# Altium schematic sheet CameraBoard.SchDoc
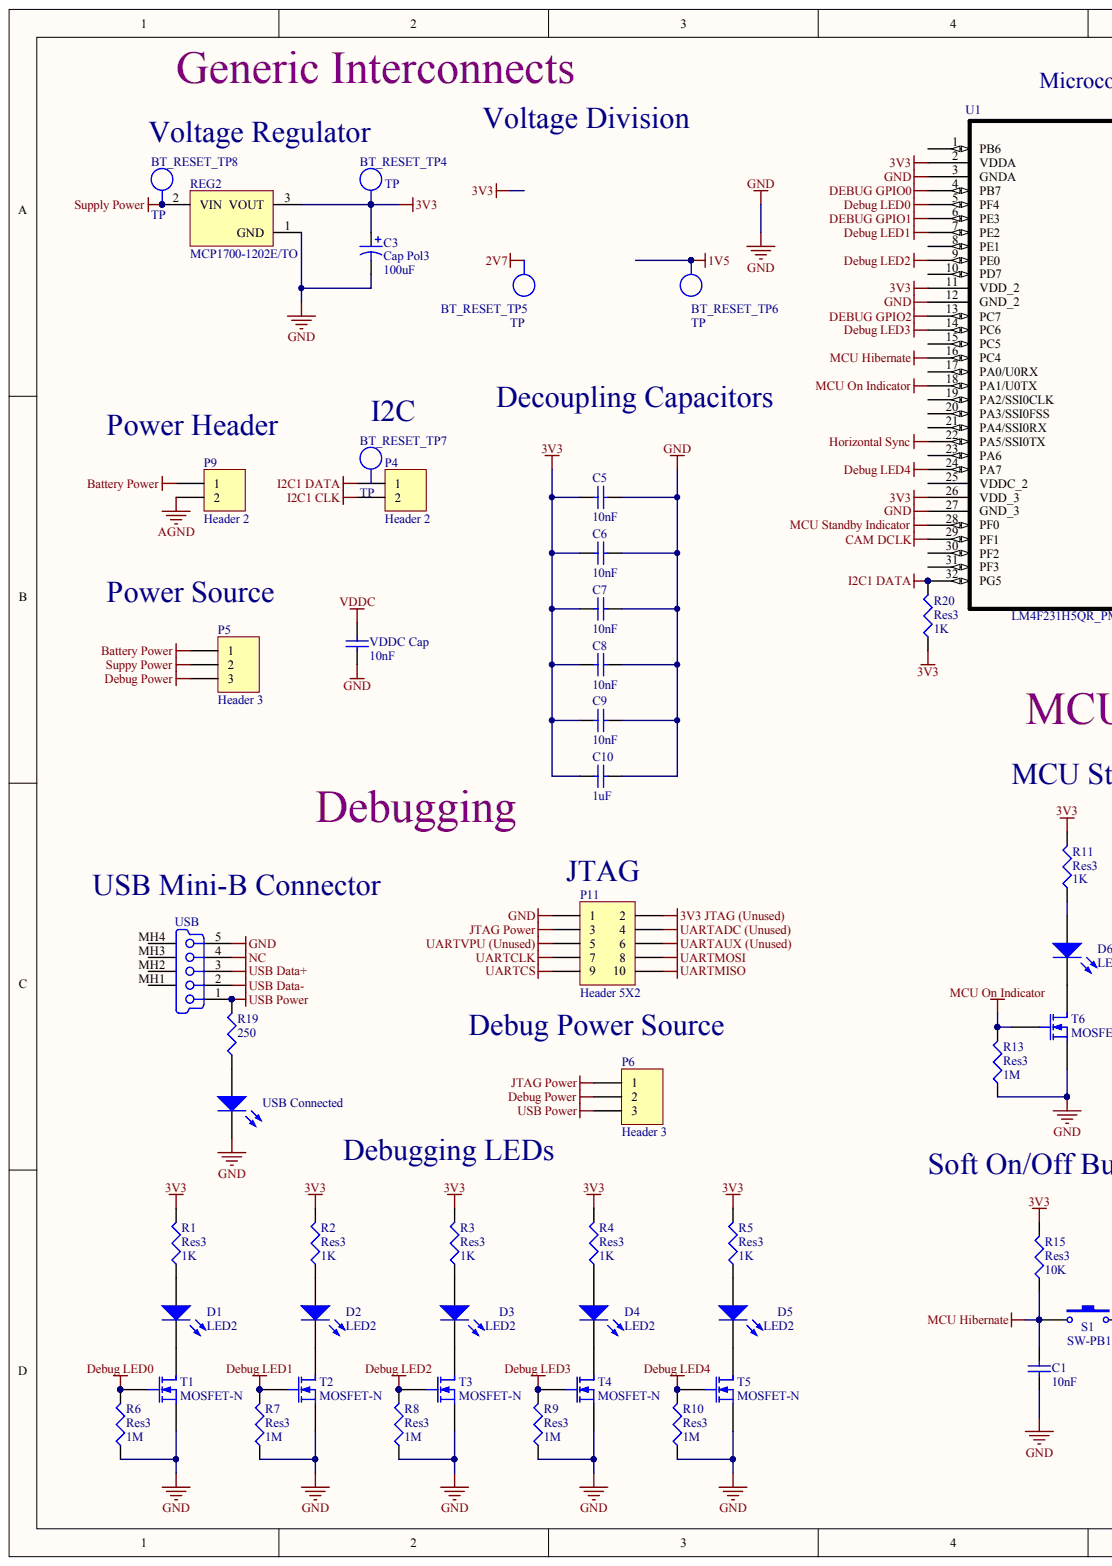
[diagram p0: CameraBoard.SchDoc, left]
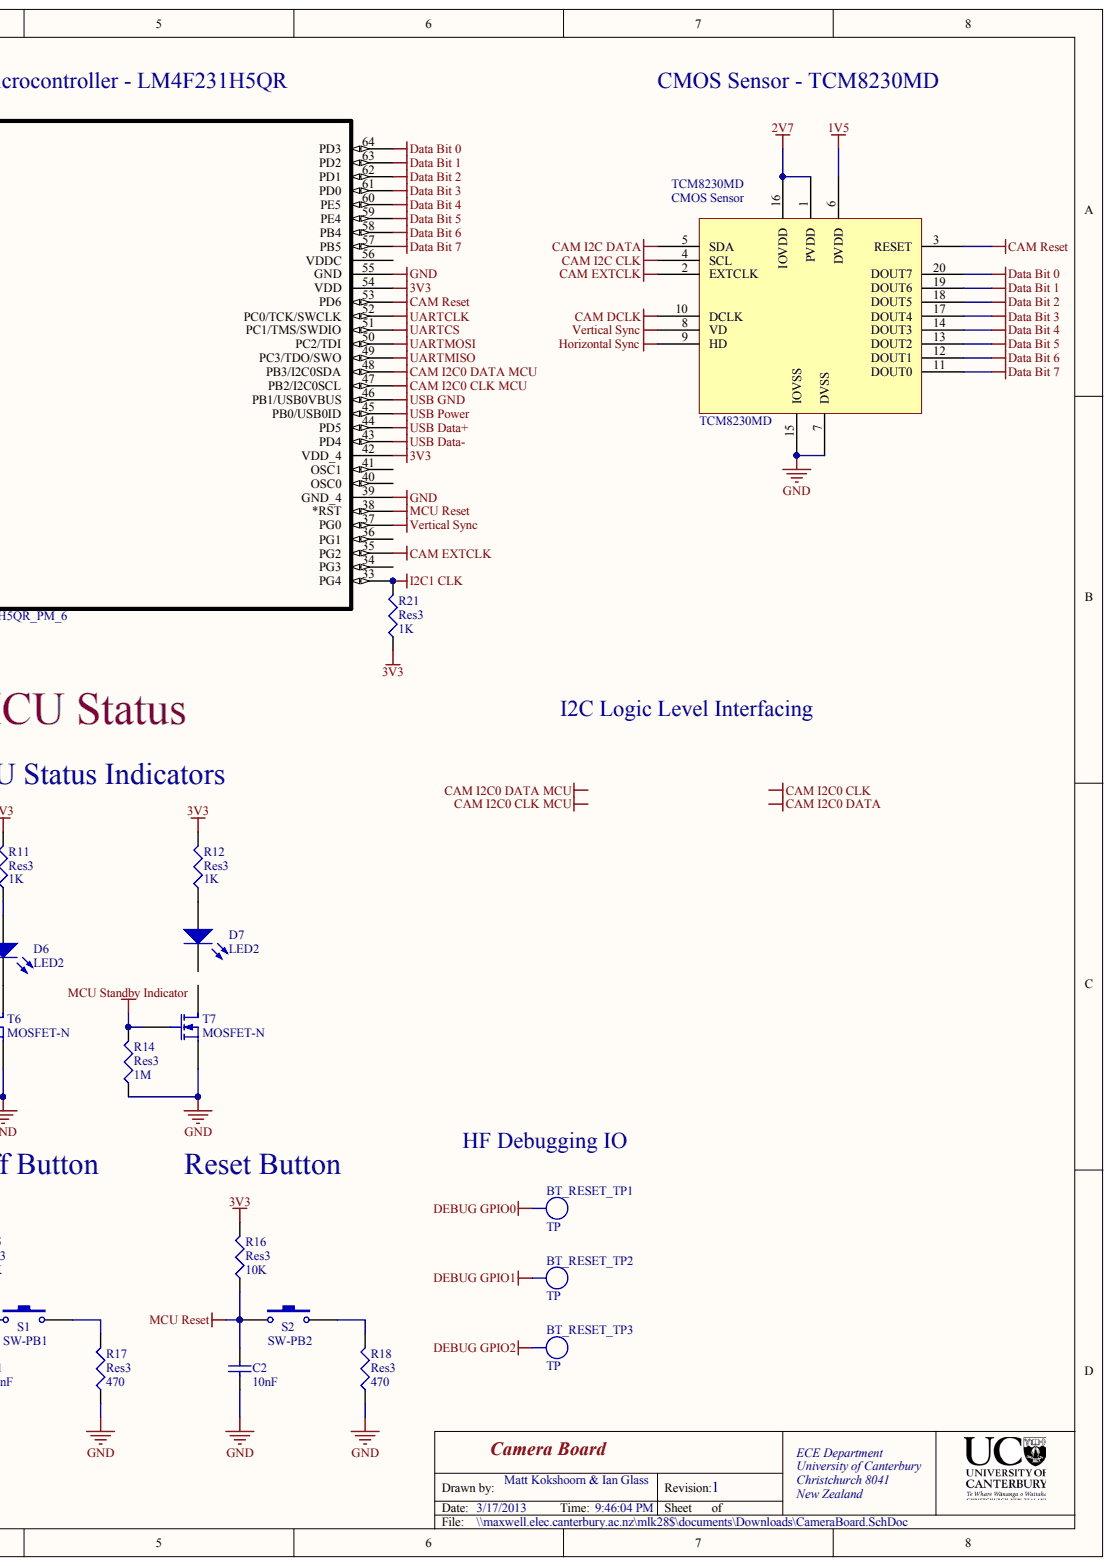
[diagram p1: CameraBoard.SchDoc, right]

## Parts
BT_RESET_TP1  TP  [TEST_POINT_4X2MM]  (no BOM)
BT_RESET_TP2  TP  [TEST_POINT_4X2MM]  (no BOM)
BT_RESET_TP3  TP  [TEST_POINT_4X2MM]  (no BOM)
BT_RESET_TP4  TP  [TEST_POINT_4X2MM]  (no BOM)
BT_RESET_TP5  TP  [TEST_POINT_4X2MM]  (no BOM)
BT_RESET_TP6  TP  [TEST_POINT_4X2MM]  (no BOM)
BT_RESET_TP7  TP  [TEST_POINT_4X2MM]  (no BOM)
BT_RESET_TP8  TP  [TEST_POINT_4X2MM]  (no BOM)
C1  value 10nF  (hidden comment: Cap Semi)
C2  value 10nF  (hidden comment: Cap Semi)
C3  Cap Pol3  value 100uF
C5  value 10nF  (hidden comment: Cap Semi)
C6  value 10nF  (hidden comment: Cap Semi)
C7  value 10nF  (hidden comment: Cap Semi)
C8  value 10nF  (hidden comment: Cap Semi)
C9  value 10nF  (hidden comment: Cap Semi)
C10  value 1uF  (hidden comment: Cap Semi)
D1  LED2
D2  LED2
D3  LED2
D4  LED2
D5  LED2
D6  LED2
D7  LED2
P4  Header 2
P5  Header 3
P6  Header 3
P9  Header 2
P11  Header 5X2
R1  Res3  value 1K
R2  Res3  value 1K
R3  Res3  value 1K
R4  Res3  value 1K
R5  Res3  value 1K
R6  Res3  value 1M
R7  Res3  value 1M
R8  Res3  value 1M
R9  Res3  value 1M
R10  Res3  value 1M
R11  Res3  value 1K
R12  Res3  value 1K
R13  Res3  value 1M
R14  Res3  value 1M
R15  Res3  value 10K
R16  Res3  value 10K
R17  Res3  value 470
R18  Res3  value 470
R19  value 250  (hidden comment: Res3)
R20  Res3  value 1K
R21  Res3  value 1K
REG2  MCP1700-1202E/TO
S1  SW-PB1
S2  SW-PB2
T1  MOSFET-N
T2  MOSFET-N
T3  MOSFET-N
T4  MOSFET-N
T5  MOSFET-N
T6  MOSFET-N
T7  MOSFET-N
TCM8230MD  CMOS Sensor
U1  LM4F231H5QR_PM_6
USB  0481900001  (value not drawn)
USB_Connected  LED3  (value not drawn)
VDDC_Cap  value 10nF  (hidden comment: Cap Semi)

## Nets
1V5: BT_RESET_TP6.1 TCM8230MD.6(DVDD)
2V7: BT_RESET_TP5.1 TCM8230MD.1(PVDD) TCM8230MD.16(IOVDD)
3V3: BT_RESET_TP4.1 C3.1 C5.2 C6.2 C7.2 C8.2 C9.2 C10.2 R1.2 R2.2 R3.2 R4.2 R5.2 R11.2 R12.2 R15.2 R16.2 R20.1 R21.1 REG2.3 U1.2(VDDA) U1.11(VDD_2) U1.26(VDD_3) U1.42(VDD_4) U1.54(VDD)
3V3 JTAG (UNUSED): P11.2
AGND: P9.2
BATTERY POWER: P5.1 P9.1
CAM DCLK: TCM8230MD.10(DCLK) U1.29(PF1)
CAM EXTCLK: TCM8230MD.2(EXTCLK) U1.35(PG2)
CAM I2C0 CLK MCU: U1.47(PB2/I2C0SCL)
CAM I2C0 DATA MCU: U1.48(PB3/I2C0SDA)
CAM I2C CLK: TCM8230MD.4(SCL)
CAM I2C DATA: TCM8230MD.5(SDA)
CAM RESET: TCM8230MD.3(RESET) U1.53(PD6)
DATA BIT 0: TCM8230MD.20(DOUT7) U1.64(PD3)
DATA BIT 1: TCM8230MD.19(DOUT6) U1.63(PD2)
DATA BIT 2: TCM8230MD.18(DOUT5) U1.62(PD1)
DATA BIT 3: TCM8230MD.17(DOUT4) U1.61(PD0)
DATA BIT 4: TCM8230MD.14(DOUT3) U1.60(PE5)
DATA BIT 5: TCM8230MD.13(DOUT2) U1.59(PE4)
DATA BIT 6: TCM8230MD.12(DOUT1) U1.58(PB4)
DATA BIT 7: TCM8230MD.11(DOUT0) U1.57(PB5)
DEBUG GPIO0: BT_RESET_TP1.1 U1.4(PB7)
DEBUG GPIO1: BT_RESET_TP2.1 U1.6(PE3)
DEBUG GPIO2: BT_RESET_TP3.1 U1.13(PC7)
DEBUG LED0: R6.2 T1.2 U1.5(PF4)
DEBUG LED1: R7.2 T2.2 U1.7(PE2)
DEBUG LED2: R8.2 T3.2 U1.9(PE0)
DEBUG LED3: R9.2 T4.2 U1.14(PC6)
DEBUG LED4: R10.2 T5.2 U1.24(PA7)
DEBUG POWER: P5.3 P6.2
GND: C1.2 C2.2 C3.2 C5.1 C6.1 C7.1 C8.1 C9.1 C10.1 P11.1 R6.1 R7.1 R8.1 R9.1 R10.1 R13.1 R14.1 R17.1 R18.1 REG2.1 T1.3 T2.3 T3.3 T4.3 T5.3 T6.3 T7.3 TCM8230MD.7(DVSS) TCM8230MD.15(IOVSS) U1.3(GNDA) U1.12(GND_2) U1.27(GND_3) U1.39(GND_4) U1.55(GND) USB.5 USB_Connected.2 VDDC_Cap.1
HORIZONTAL SYNC: TCM8230MD.9(HD) U1.22(PA5/SSI0TX)
I2C1 CLK: P4.2 R21.2 U1.33(PG4)
I2C1 DATA: P4.1 R20.2 U1.32(PG5)
JTAG POWER: P6.1 P11.3
MCU HIBERNATE: C1.1 R15.1 S1.1 U1.16(PC4)
MCU ON INDICATOR: R13.2 T6.2 U1.18(PA1/U0TX)
MCU RESET: C2.1 R16.1 S2.1 U1.38(*RST)
MCU STANDBY INDICATOR: R14.2 T7.2 U1.28(PF0)
NC: USB.4
SUPPLY POWER: BT_RESET_TP8.1 REG2.2
SUPPY POWER: P5.2
UARTADC (UNUSED): P11.4
UARTAUX (UNUSED): P11.6
UARTCLK: P11.7 U1.52(PC0/TCK/SWCLK)
UARTCS: P11.9 U1.51(PC1/TMS/SWDIO)
UARTMISO: P11.10 U1.49(PC3/TDO/SWO)
UARTMOSI: P11.8 U1.50(PC2/TDI)
UARTVPU (UNUSED): P11.5
USB DATA+: U1.44(PD5) USB.3
USB DATA-: U1.43(PD4) USB.2
USB GND: U1.46(PB1/USB0VBUS)
USB POWER: P6.3 R19.2 U1.45(PB0/USB0ID) USB.1
VDDC: VDDC_Cap.2
VERTICAL SYNC: TCM8230MD.8(VD) U1.37(PG0)
NetD1_1: D1.1 R1.1
NetD1_2: D1.2 T1.1
NetD2_1: D2.1 R2.1
NetD2_2: D2.2 T2.1
NetD3_1: D3.1 R3.1
NetD3_2: D3.2 T3.1
NetD4_1: D4.1 R4.1
NetD4_2: D4.2 T4.1
NetD5_1: D5.1 R5.1
NetD5_2: D5.2 T5.1
NetD6_1: D6.1 R11.1
NetD6_2: D6.2 T6.1
NetD7_1: D7.1 R12.1
NetR17_2: R17.2 S1.2
NetR18_2: R18.2 S2.2
NetR19_1: R19.1 USB_Connected.1
CAM I2C0 CLK:
CAM I2C0 DATA:

Not connected: BT_RESET_TP7 1; D7 2; T7 1; U1 1(PB6) 8(PE1) 10(PD7) 15(PC5) 17(PA0/U0RX) 19(PA2/SSI0CLK) 20(PA3/SSI0FSS) 21(PA4/SSI0RX) 23(PA6) 25(VDDC_2) 30(PF2) 31(PF3) 34(PG3) 36(PG1) 40(OSC0) 41(OSC1) 56(VDDC); USB MH1 MH2 MH3 MH4

## Library data of the parts
BT_RESET_TP1-BT_RESET_TP8 = TP_TESTPOINT: LatestRevisionDate: 29 May 08; Manufacturer PN: *; Manufacturer: *; Supplier 1: Digi-Key; Supplier Part Number 1: 5016KTR-ND; Value: TP; Part Number: TP_TESTPOINT; Component Type: Standard (No BOM); Designator: TP_TESTPOINT; Pin Count: 1
USB = (no symbol name): PCB_Layout: Complies with Manufacturer's Recommendation.; Published: 21-Sep-2007; DatasheetVersion: revA, May-2004; PackageVersion: May-2004; PackageReference: 481900001; PackageDescription: USB Mini-B, Sinking Type, SM; 5 Position; Right Angle, Body 11.45 x 11.8 x 3.9 mm (LxWxH); ComponentLink1Description: Manufacturer Link; ComponentLink1URL: http://www.molex.com/; ComponentLink2Description: Datasheet; ComponentLink2URL: http://www.molex.com/cmc_upload/0/000/895/958/SD-48190-001RevA.pdf; Publisher: Altium Limited
C1-C3 C5-C10 D1-D7 P4-P6 P9 P11 R1-R21 REG2 S1 S2 T1-T7 TCM8230MD U1 USB_Connected VDDC_Cap = (no symbol name)
  C1 C2 C5-C10 VDDC_Cap: Published: 8-Jun-2000; LatestRevisionDate: 29-May-2009; LatestRevisionNote: IPC-7351 Footprint Added.; PackageReference: C1206; PackageDescription: Chip Capacitor
  C3: Published: 8-Jun-2000; LatestRevisionDate: 29-May-2009; LatestRevisionNote: IPC-7351 Footprint Added.; PackageReference: C0805; PackageDescription: Chip Capacitor
  D1-D7: Published: 8-Jun-2000; LatestRevisionDate: 17-Jul-2002; LatestRevisionNote: Re-released for DXP Platform.; PackageVersion: Feb-2002; PackageReference: 3.2X1.6X1.1
  P4-P6 P9 P11: LatestRevisionDate: 17-Jul-2002; LatestRevisionNote: Re-released for DXP Platform.
  R1-R21: Published: 8-Jun-2000; LatestRevisionDate: 29-May-2009; LatestRevisionNote: IPC-7351 Footprint Added.; PackageReference: J1-0603; PackageDescription: Chip Resistor
  REG2: FirstPublished: 29-Jan-2009; DatasheetVersion: revB, Feb-2007; PackageVersion: revBB, Aug-2009; PackageReference: TO-92-TO3; PackageDescription: 3-Lead Plastic Transistor Outline (TO) [TO-92]; Supplier 1: Digi-Key; Supplier Part Number 1: MCP1700-1202E/TO-ND; Supplier 2: Newark; Supplier Part Number 2: 88H9800; ComponentLink1Description: Datasheet; ComponentLink1URL: http://ww1.microchip.com/downloads/en/DeviceDoc/21826b.pdf; ComponentLink2Description: Packaging Specification; ComponentLink2URL: http://www.microchip.com/stellent/groups/techpub_sg/documents/packagingspec/en012702.pdf; ComponentLink3Description: Microchip.com Home; ComponentLink3URL: http://www.microchip.com/
  S1 S2: Published: 24-Mar-1999; LatestRevisionDate: 17-Jul-2002; LatestRevisionNote: Re-released for DXP Platform.; PackageReference: SPST-2
  T1-T7: Published: 8-Jun-2000; LatestRevisionDate: 17-Jul-2002; LatestRevisionNote: Re-released for DXP Platform.; PackageVersion: 1995/1996; PackageReference: E3
  USB_Connected: Published: 8-Jun-2000; LatestRevisionDate: 17-Dec-2003; LatestRevisionNote: PCB Footprint replaced.; DatasheetVersion: 16-Apr-2002; PackageVersion: 16-Apr-2002; PackageReference: 3.5X2.8X1.9; PackageDescription: SM LED; 2 C-Bend Leads; Body 3.5 x 2.8 x 1.9 mm, inc leads (LxWxH typ)
  C1-C3 C5-C10 D1-D7 P4-P6 P9 P11 R1-R21 REG2 S1 S2 T1-T7 USB_Connected VDDC_Cap: Publisher: Altium Limited
